# Altium schematic sheet ct_userio.SchDoc
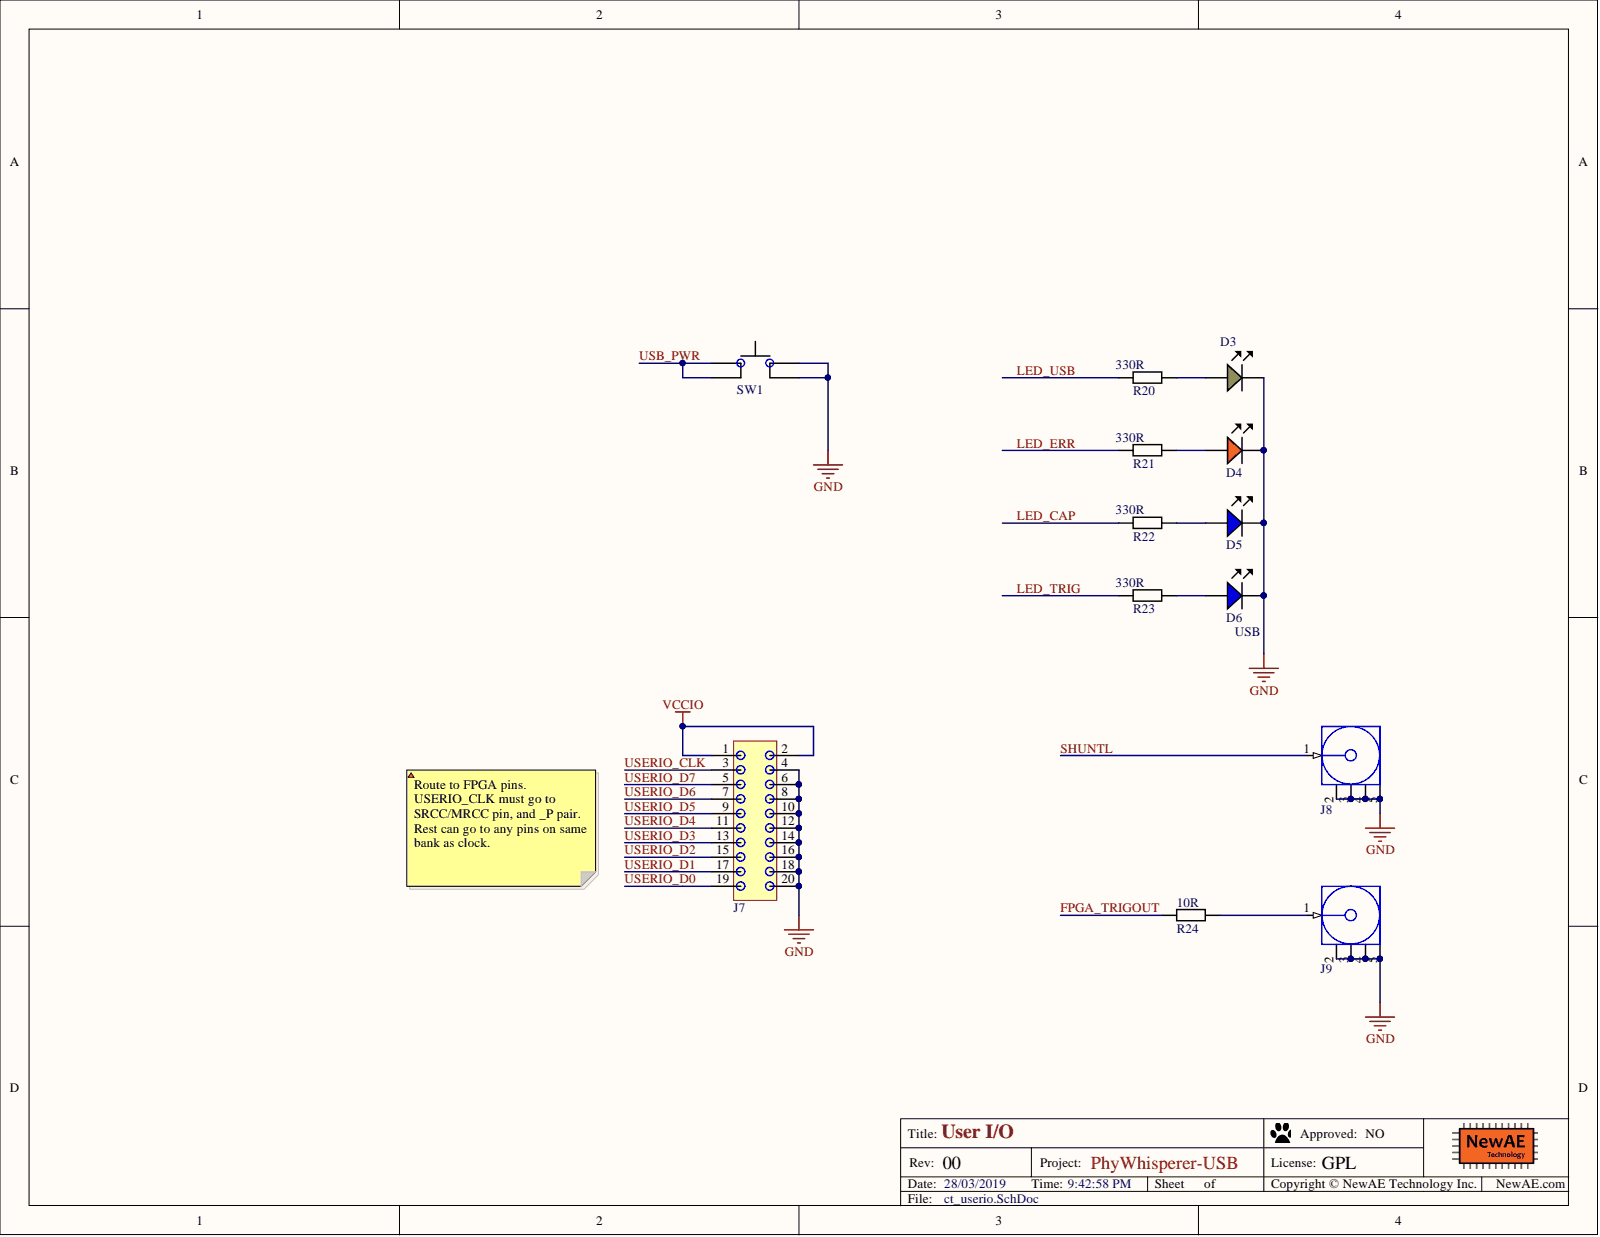
[diagram p0: ct_userio.SchDoc]

## Parts
D3  (no value)  [LED-0603-GREEN]
D4  LED, 0603, Red  [LED-0603-RED]  (value not drawn)
D5  LED, 0603, Blue  [LED-0603-BLUE]  (value not drawn)
D6  USB  [LED-0603-BLUE]
J7  EW-10-09-G-D-300  [EW-10-09-G-D-300]  (value not drawn)
J8  MCX 733660061  [CONN_MCX_733660061]  (value not drawn)
J9  MCX 733660061  [CONN_MCX_733660061]  (value not drawn)
R20  330R  [0603]
R21  330R  [0603]
R22  330R  [0603]
R23  330R  [0603]
R24  10R  [0603]
SW1  KSC741J LFS  [CK_KSC7J]  (value not drawn)

## Nets
GND: D3.K D4.K D5.K D6.K J7.4 J7.6 J7.8 J7.10 J7.12 J7.14 J7.16 J7.18 J7.20 J8.2 J8.3 J8.4 J8.5 J9.2 J9.3 J9.4 J9.5 SW1.2 SW1.3
VCCIO: J7.1 J7.2
FPGA_TRIGOUT: R24.1
LED_CAP: R22.1
LED_ERR: R21.1
LED_TRIG: R23.1
LED_USB: R20.1
SHUNTL: J8.1
USB_PWR: SW1.1 SW1.4
USERIO_CLK: J7.3
USERIO_D0: J7.19
USERIO_D1: J7.17
USERIO_D2: J7.15
USERIO_D3: J7.13
USERIO_D4: J7.11
USERIO_D5: J7.9
USERIO_D6: J7.7
USERIO_D7: J7.5
NetD3_A: D3.A R20.2
NetD4_A: D4.A R21.2
NetD5_A: D5.A R22.2
NetD6_A: D6.A R23.2
NetJ9_1: J9.1 R24.2

## Library data of the parts
D3 = LEDCHIP_0603_GREEN: Chip LED, Green; Manufacture 1: Kingbright; Manufacture Part Number 1: APT1608CGCK; Component Group: Optical; Database Table Name: main; PP Material Stack: 16; PP Rotation: -90; Component Kind: Standard; Component Type: Standard; Library Name: NewAE_AltiumLib.DBLib; Mounting Technology: SMT; Part Number: LEDCHIP_0603_GREEN; Pin Count: 2; Supplier 1: Digi-Key; Supplier Part Number 1: 754-1116-1-ND
D4 = LEDCHIP_0603_RED: Chip LED, Red; Manufacture 1: Kingbright; Manufacture Part Number 1: APT1608SRCPRV; Component Group: Optical; Database Table Name: main; PP Material Stack: 15; PP Rotation: -90; Component Kind: Standard; Component Type: Standard; Library Name: NewAE_AltiumLib.DBLib; Mounting Technology: SMT; Part Number: LEDCHIP_0603_RED; Pin Count: 2; Supplier 1: Digi-Key; Supplier Part Number 1: 754-1122-1-ND
D5 D6 = LEDCHIP_0603_BLUE: Chip LED, Blue; Manufacture 1: Kingbright; Component Group: Optical; Database Table Name: main; Component Kind: Standard; Component Type: Standard; Library Name: NewAE_AltiumLib.DBLib; Mounting Technology: SMT; Part Number: LEDCHIP_0603_BLUE; Pin Count: 2
J7 = EW-10-09-G-D-300: 20-Pin Header, High-Top; Component Group: Connector; Database Table Name: main; PP Material Stack: 0; Component Kind: Standard; Component Type: Standard; Library Name: NewAE_AltiumLib.DBLib; Manufacture Part Number 1: EW-10-09-G-D-300; Part Number: EW-10-09-G-D-300; Pin Count: 20; PP Rotation: 0; Supplier 1: Mouser
J8 J9 = 733660061: MCX Straight; Manufacture 1: Molex; Manufacture Part Number 1: 73366-0061; Component Group: Connector; Database Table Name: main; PP Material Stack: 0; Component Kind: Standard; Component Type: Standard; Library Name: NewAE_AltiumLib.DBLib; Mounting Technology: Through-hole; Part Number: 733660061; Pin Count: 5; PP Rotation: 0; RoHS: Yes; Supplier 1: Digi-Key; Supplier Part Number 1: WM5541-ND
R20-R23 = RCHIP-330R-0603: 330R res, 0603, 1%, 1/10W; Manufacture 1: Yageo; Manufacture Part Number 1: RC0603FR-07330RL; Case-Metric: 1608; Component Group: Resistor; Database Table Name: main; Rated Power: 0.1W; Rated Voltage: 75VDC; Case-EIA: 0603; Component Kind: Standard; Component Type: Standard; Library Name: NewAE_AltiumLib.DBLib; Mounting Technology: SMT; Part Number: RCHIP-330R-0603; Pin Count: 2; Supplier 1: Digi-Key; Supplier Part Number 1: 311-330HRCT-ND; Temperature Range: -55C to 155C; Tolerance: 1%; Value: 330R
R24 = RCHIP-10R-0603: 10R res, 0603, 1%, 1/10W; Manufacture 1: Yageo; Manufacture Part Number 1: RC0603FR-0710RL; Case-Metric: 1608; Component Group: Resistor; Database Table Name: main; Rated Power: 0.1W; Rated Voltage: 75VDC; Case-EIA: 0603; Component Kind: Standard; Component Type: Standard; Library Name: NewAE_AltiumLib.DBLib; Mounting Technology: SMT; Part Number: RCHIP-10R-0603; Pin Count: 2; Supplier 1: Digi-Key; Supplier Part Number 1: 311-10.0HRCT-ND; Temperature Range: -55C to 155C; Tolerance: 1%; Value: 10R
SW1 = TACTSW-KSC741J: SWITCH TACTILE SPST-NO 0.01A 32V; Manufacture 1: C&K; Database Table Name: main; PP Material Stack: 0; Component Group: Switch; Component Kind: Standard; Component Type: Standard; Library Name: NewAE_AltiumLib.DBLib; Manufacture Part Number 1: KSC741J LFS; Mounting Technology: SMT; Part Number: TACTSW-KSC741J; Pin Count: 4; PP Rotation: 0; RoHS: Yes; Supplier 1: Digi-Key; Supplier Part Number 1: CKN10309CT-ND
